# Altium schematic sheet 01_FPGA_Core.SchDoc
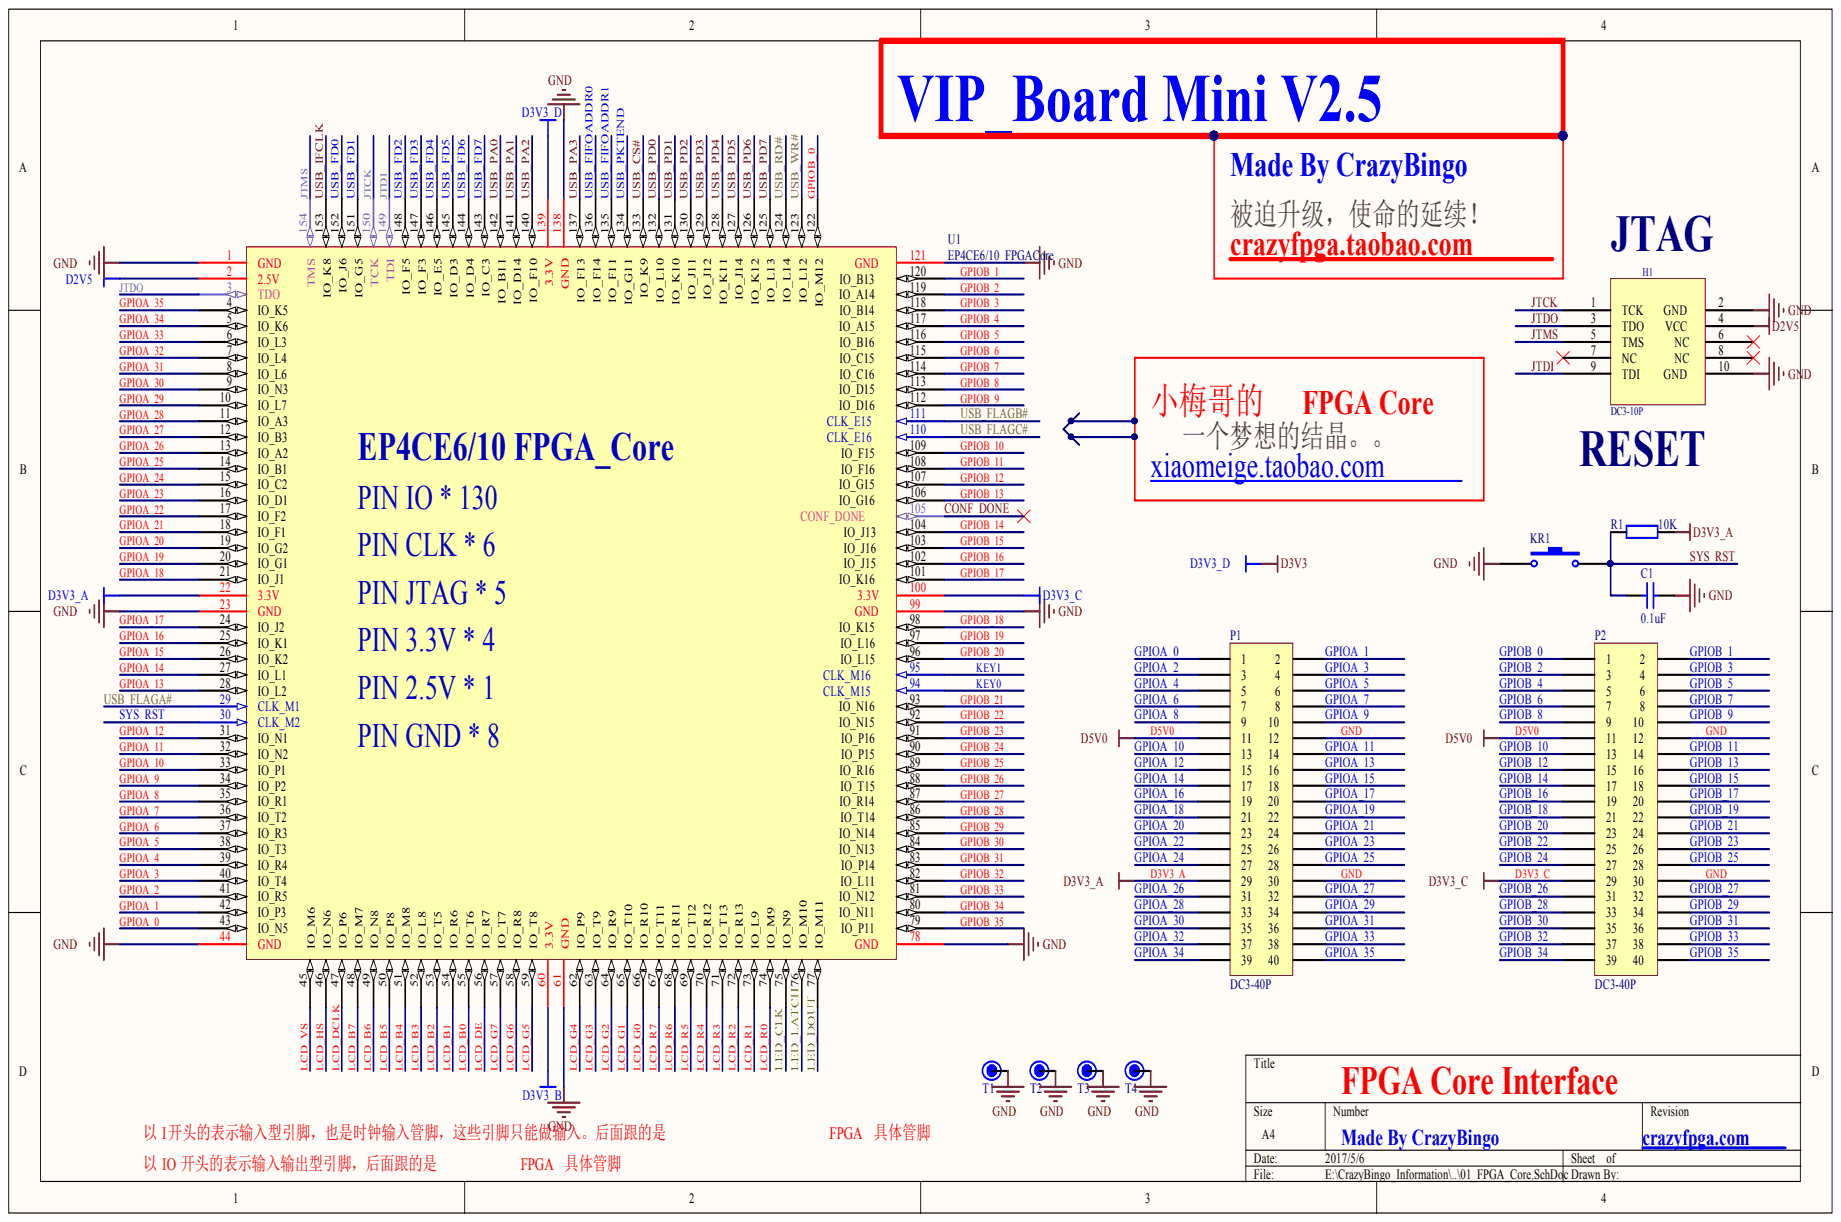
[diagram p0: 01_FPGA_Core.SchDoc]

## Parts
C1  0.1uF  [R0603]
H1  DC3-10P  [DC3-10LAY]
KR1  SW-PB  [KEY2]  (value not drawn)
P1  DC3-40P  [DC3-40]
P2  DC3-40P  [DC3-40]
R1  10K  [R0603]
T1  Pin_M3  [Pin_M3]  (value not drawn)
T2  Pin_M3  [Pin_M3]  (value not drawn)
T3  Pin_M3  [Pin_M3]  (value not drawn)
T4  Pin_M3  [Pin_M3]  (value not drawn)
U1  EP4CE6/10_FPGACore  [EP4CE6/10_FPGACore]

## Nets
D2V5: H1.4(VCC) U1.2(2.5V)
D3V3: U1.139(3.3V)
D3V3_B: U1.60(3.3V)
CONF_DONE: U1.105
D3V3_A: P1.29 R1.2 U1.22(3.3V)
D3V3_C: P2.29 U1.100(3.3V)
D5V0: P1.11 P2.11
GND: C1.1 H1.2(GND) H1.10(GND) KR1.1 P1.12 P1.30 P2.12 P2.30 T1.1 T2.1 T3.1 T4.1 U1.1(GND) U1.23(GND) U1.44(GND) U1.61(GND) U1.78(GND) U1.99(GND) U1.121(GND) U1.138(GND)
GPIOA_0: P1.1 U1.43(IO_N5)
GPIOA_1: P1.2 U1.42(IO_P3)
GPIOA_2: P1.3 U1.41(IO_R5)
GPIOA_3: P1.4 U1.40(IO_T4)
GPIOA_4: P1.5 U1.39(IO_R4)
GPIOA_5: P1.6 U1.38(IO_T3)
GPIOA_6: P1.7 U1.37(IO_R3)
GPIOA_7: P1.8 U1.36(IO_T2)
GPIOA_8: P1.9 U1.35(IO_R1)
GPIOA_9: P1.10 U1.34(IO_P2)
GPIOA_10: P1.13 U1.33(IO_P1)
GPIOA_11: P1.14 U1.32(IO_N2)
GPIOA_12: P1.15 U1.31(IO_N1)
GPIOA_13: P1.16 U1.28(IO_L2)
GPIOA_14: P1.17 U1.27(IO_L1)
GPIOA_15: P1.18 U1.26(IO_K2)
GPIOA_16: P1.19 U1.25(IO_K1)
GPIOA_17: P1.20 U1.24(IO_J2)
GPIOA_18: P1.21 U1.21(IO_J1)
GPIOA_19: P1.22 U1.20(IO_G1)
GPIOA_20: P1.23 U1.19(IO_G2)
GPIOA_21: P1.24 U1.18(IO_F1)
GPIOA_22: P1.25 U1.17(IO_F2)
GPIOA_23: P1.26 U1.16(IO_D1)
GPIOA_24: P1.27 U1.15(IO_C2)
GPIOA_25: P1.28 U1.14(IO_B1)
GPIOA_26: P1.31 U1.13(IO_A2)
GPIOA_27: P1.32 U1.12(IO_B3)
GPIOA_28: P1.33 U1.11(IO_A3)
GPIOA_29: P1.34 U1.10(IO_L7)
GPIOA_30: P1.35 U1.9(IO_N3)
GPIOA_31: P1.36 U1.8(IO_L6)
GPIOA_32: P1.37 U1.7(IO_L4)
GPIOA_33: P1.38 U1.6(IO_L3)
GPIOA_34: P1.39 U1.5(IO_K6)
GPIOA_35: P1.40 U1.4(IO_K5)
GPIOB_0: P2.1 U1.122(IO_M12)
GPIOB_1: P2.2 U1.120(IO_B13)
GPIOB_2: P2.3 U1.119(IO_A14)
GPIOB_3: P2.4 U1.118(IO_B14)
GPIOB_4: P2.5 U1.117(IO_A15)
GPIOB_5: P2.6 U1.116(IO_B16)
GPIOB_6: P2.7 U1.115(IO_C15)
GPIOB_7: P2.8 U1.114(IO_C16)
GPIOB_8: P2.9 U1.113(IO_D15)
GPIOB_9: P2.10 U1.112(IO_D16)
GPIOB_10: P2.13 U1.109(IO_F15)
GPIOB_11: P2.14 U1.108(IO_F16)
GPIOB_12: P2.15 U1.107(IO_G15)
GPIOB_13: P2.16 U1.106(IO_G16)
GPIOB_14: P2.17 U1.104(IO_J13)
GPIOB_15: P2.18 U1.103(IO_J16)
GPIOB_16: P2.19 U1.102(IO_J15)
GPIOB_17: P2.20 U1.101(IO_K16)
GPIOB_18: P2.21 U1.98(IO_K15)
GPIOB_19: P2.22 U1.97(IO_L16)
GPIOB_20: P2.23 U1.96(IO_L15)
GPIOB_21: P2.24 U1.93(IO_N16)
GPIOB_22: P2.25 U1.92(IO_N15)
GPIOB_23: P2.26 U1.91(IO_P16)
GPIOB_24: P2.27 U1.90(IO_P15)
GPIOB_25: P2.28 U1.89(IO_R16)
GPIOB_26: P2.31 U1.88(IO_T15)
GPIOB_27: P2.32 U1.87(IO_R14)
GPIOB_28: P2.33 U1.86(IO_T14)
GPIOB_29: P2.34 U1.85(IO_N14)
GPIOB_30: P2.35 U1.84(IO_N13)
GPIOB_31: P2.36 U1.83(IO_P14)
GPIOB_32: P2.37 U1.82(IO_L11)
GPIOB_33: P2.38 U1.81(IO_N12)
GPIOB_34: P2.39 U1.80(IO_N11)
GPIOB_35: P2.40 U1.79(IO_P11)
JTCK: H1.1(TCK) U1.150(TCK)
JTDI: H1.9(TDI) U1.149(TDI)
JTDO: H1.3(TDO) U1.3(TDO)
JTMS: H1.5(TMS) U1.154(TMS)
KEY0: U1.94(CLK_M15)
KEY1: U1.95(CLK_M16)
LCD_B0: U1.55(IO_T6)
LCD_B1: U1.54(IO_R6)
LCD_B2: U1.53(IO_T5)
LCD_B3: U1.52(IO_L8)
LCD_B4: U1.51(IO_M8)
LCD_B5: U1.50(IO_P8)
LCD_B6: U1.49(IO_N8)
LCD_B7: U1.48(IO_M7)
LCD_DCLK: U1.47(IO_P6)
LCD_DE: U1.56(IO_R7)
LCD_G0: U1.66(IO_R10)
LCD_G1: U1.65(IO_T10)
LCD_G2: U1.64(IO_R9)
LCD_G3: U1.63(IO_T9)
LCD_G4: U1.62(IO_P9)
LCD_G5: U1.59(IO_T8)
LCD_G6: U1.58(IO_R8)
LCD_G7: U1.57(IO_T7)
LCD_HS: U1.46(IO_N6)
LCD_R0: U1.74(IO_M9)
LCD_R1: U1.73(IO_L9)
LCD_R2: U1.72(IO_R13)
LCD_R3: U1.71(IO_T13)
LCD_R4: U1.70(IO_R12)
LCD_R5: U1.69(IO_T12)
LCD_R6: U1.68(IO_R11)
LCD_R7: U1.67(IO_T11)
LCD_VS: U1.45(IO_M6)
LED_CLK: U1.75(IO_N9)
LED_DOUT: U1.77(IO_M11)
LED_LATCH: U1.76(IO_M10)
SYS_RST: C1.2 KR1.2 R1.1 U1.30(CLK_M2)
USB_CS#: U1.133(IO_K9)
USB_FD0: U1.152(IO_J6)
USB_FD1: U1.151(IO_G5)
USB_FD2: U1.148(IO_F5)
USB_FD3: U1.147(IO_F3)
USB_FD4: U1.146(IO_E5)
USB_FD5: U1.145(IO_D3)
USB_FD6: U1.144(IO_D4)
USB_FD7: U1.143(IO_C3)
USB_FIFOADDR0: U1.136(IO_F14)
USB_FIFOADDR1: U1.135(IO_F11)
USB_FLAGA#: U1.29(CLK_M1)
USB_FLAGB#: U1.111(CLK_E15)
USB_FLAGC#: U1.110(CLK_E16)
USB_IFCLK: U1.153(IO_K8)
USB_PA0: U1.142(IO_B11)
USB_PA1: U1.141(IO_D14)
USB_PA2: U1.140(IO_F10)
USB_PA3: U1.137(IO_F13)
USB_PD0: U1.132(IO_L10)
USB_PD1: U1.131(IO_K10)
USB_PD2: U1.130(IO_J11)
USB_PD3: U1.129(IO_J12)
USB_PD4: U1.128(IO_K11)
USB_PD5: U1.127(IO_J14)
USB_PD6: U1.126(IO_K12)
USB_PD7: U1.125(IO_L13)
USB_PKTEND: U1.134(IO_G11)
USB_RD#: U1.124(IO_L14)
USB_WR#: U1.123(IO_L12)
N00143:
N00144:

Not connected: H1 6(NC) 7(NC) 8(NC)

## Library data of the parts
C1 = CAP: Component Kind: Standard; Library Name: Crazy_PCB.SchLib
H1 = JTAG10: DC3-10P 接插件; Component Kind: Standard; Library Name: Crazy_PCB.SchLib
KR1 = SW-PB: Switch; Component Kind: Standard; LatestRevisionDate: 17-Jul-2002; LatestRevisionNote: Re-released for DXP Platform.; Library Name: Miscellaneous Devices.IntLib; PackageReference: SPST-2; Published: 24-Mar-1999; Publisher: Altium Limited
P1 P2 = Header 20X2: DC3-10P 接插件; Component Kind: Standard; LatestRevisionDate: 17-Jul-2002; LatestRevisionNote: Re-released for DXP Platform.; Library Name: Miscellaneous Connectors.IntLib; Signal Integrity: Connector; Publisher: Altium Limited
R1 = RES: Resistor; Value: 1K; Component Kind: Standard; Library Name: Crazy_PCB.SchLib; Published: 8-Jun-2000; Revision: July-2002: Re-released for DXP Platform.; Publisher: Altium Limited
T1-T4 = Pin_M3: Component Kind: Standard; Library Name: Crazy_PCB.SchLib
U1 = EP4CE6/10_FPGACore: Component Kind: Standard; Library Name: VIP_Board_Mini.SCHLIB
